# Altium schematic sheet Buzzer.SchDoc
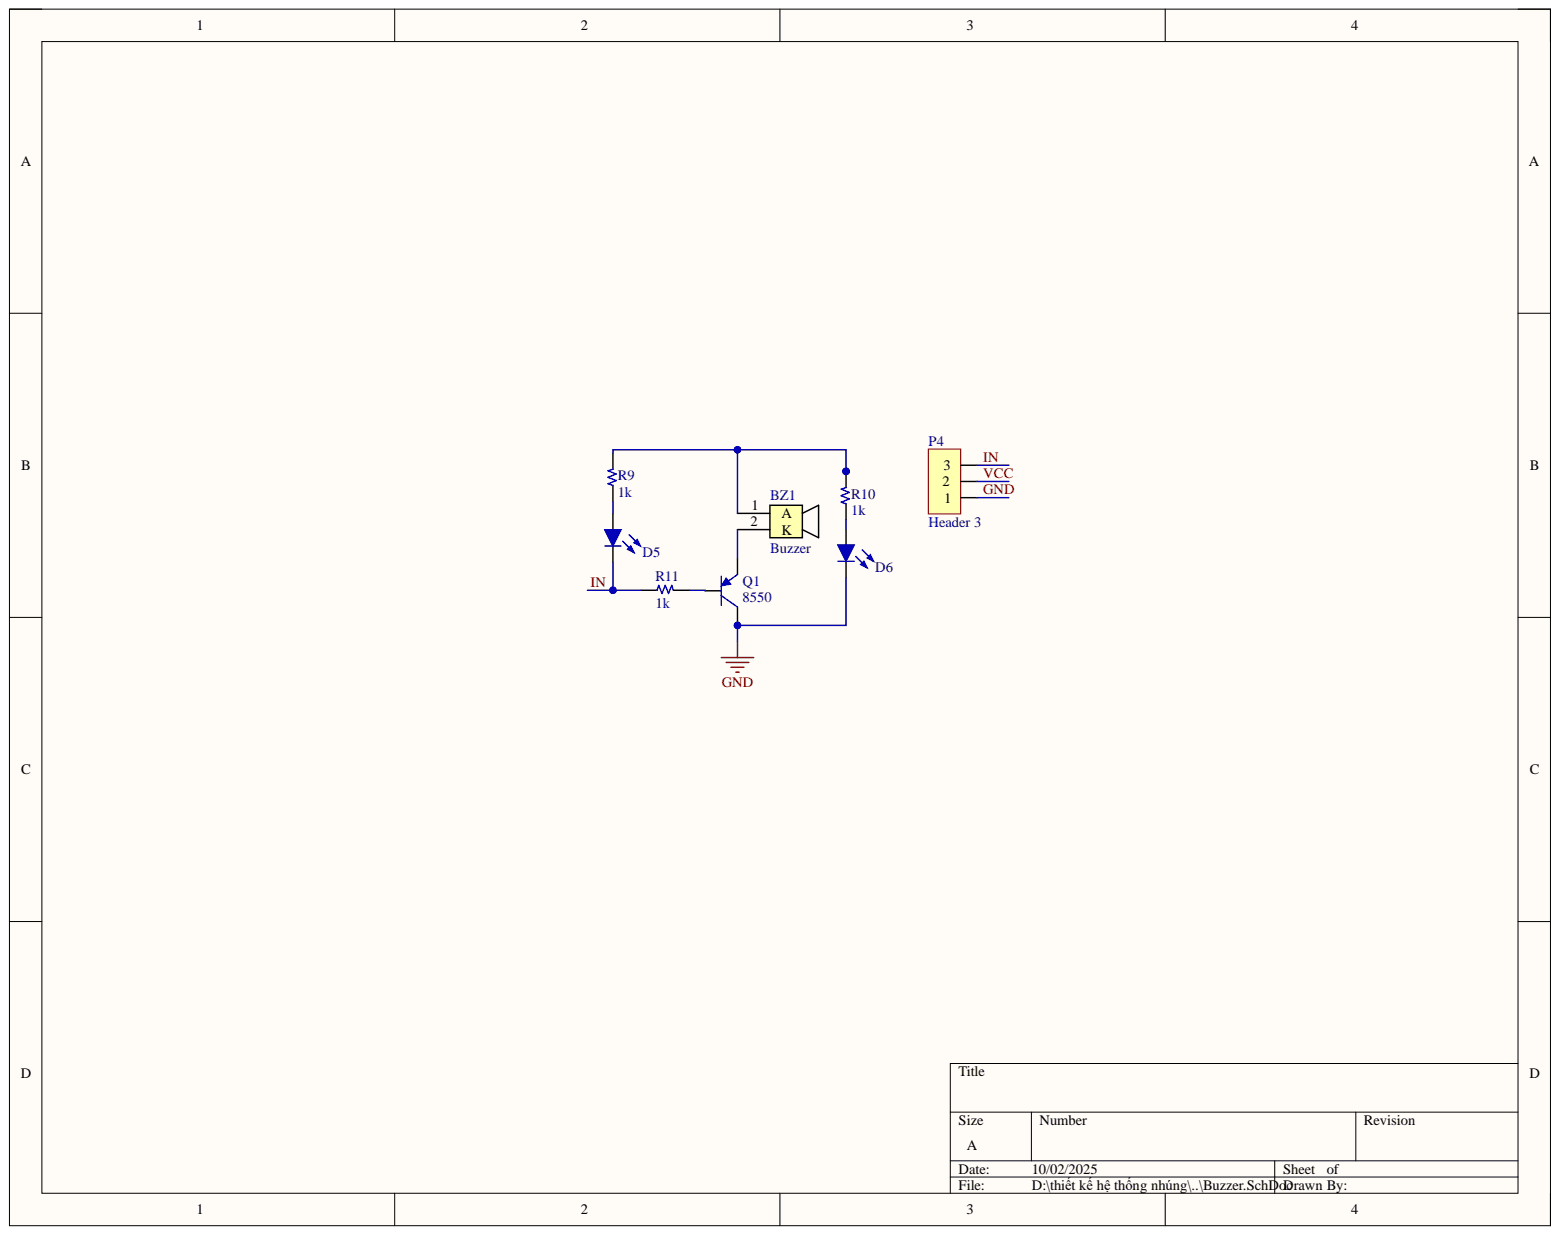
[diagram p0: Buzzer.SchDoc]

## Parts
BZ1  Buzzer  [Buzzer SMD]
D5  Led  [Led 0603 - red]  (value not drawn)
D6  Led  [Led 0603 - red]  (value not drawn)
P4  Header 3  [HDR/Header/3]
Q1  8550  [SOT-23]
R9  1k  [R 0603]
R10  1k  [R 0603]
R11  1k  [R 0603]

## Nets
GND: D6.2 P4.1 Q1.3
IN: D5.2 P4.3 R11.1
VCC: P4.2
NetBZ1_1: BZ1.1 R9.2 R10.2
NetBZ1_2: BZ1.2 Q1.2
NetD5_1: D5.1 R9.1
NetD6_1: D6.1 R10.1
NetQ1_1: Q1.1 R11.2

## Library data of the parts
D5 D6 = Led: Typical LED; Component Kind: Standard; Library Name: led.SchLib
P4 = Header 3: Header, 3-Pin; Component Kind: Standard; Library Name: connectors.SchLib
Q1 = Transistor PNP: PNP Bipolar Transistor; Component Kind: Standard; Library Name: chipfc_libs.SchLib
R9-R11 = Resistor: Component Kind: Standard; Description: Resistor; Library Name: chipfc_libs.SchLib
BZ1 = Buzzer: Component Kind: Standard; Library Name: chipfc_libs.SchLib
